# Altium schematic sheet cwh_userio.SchDoc
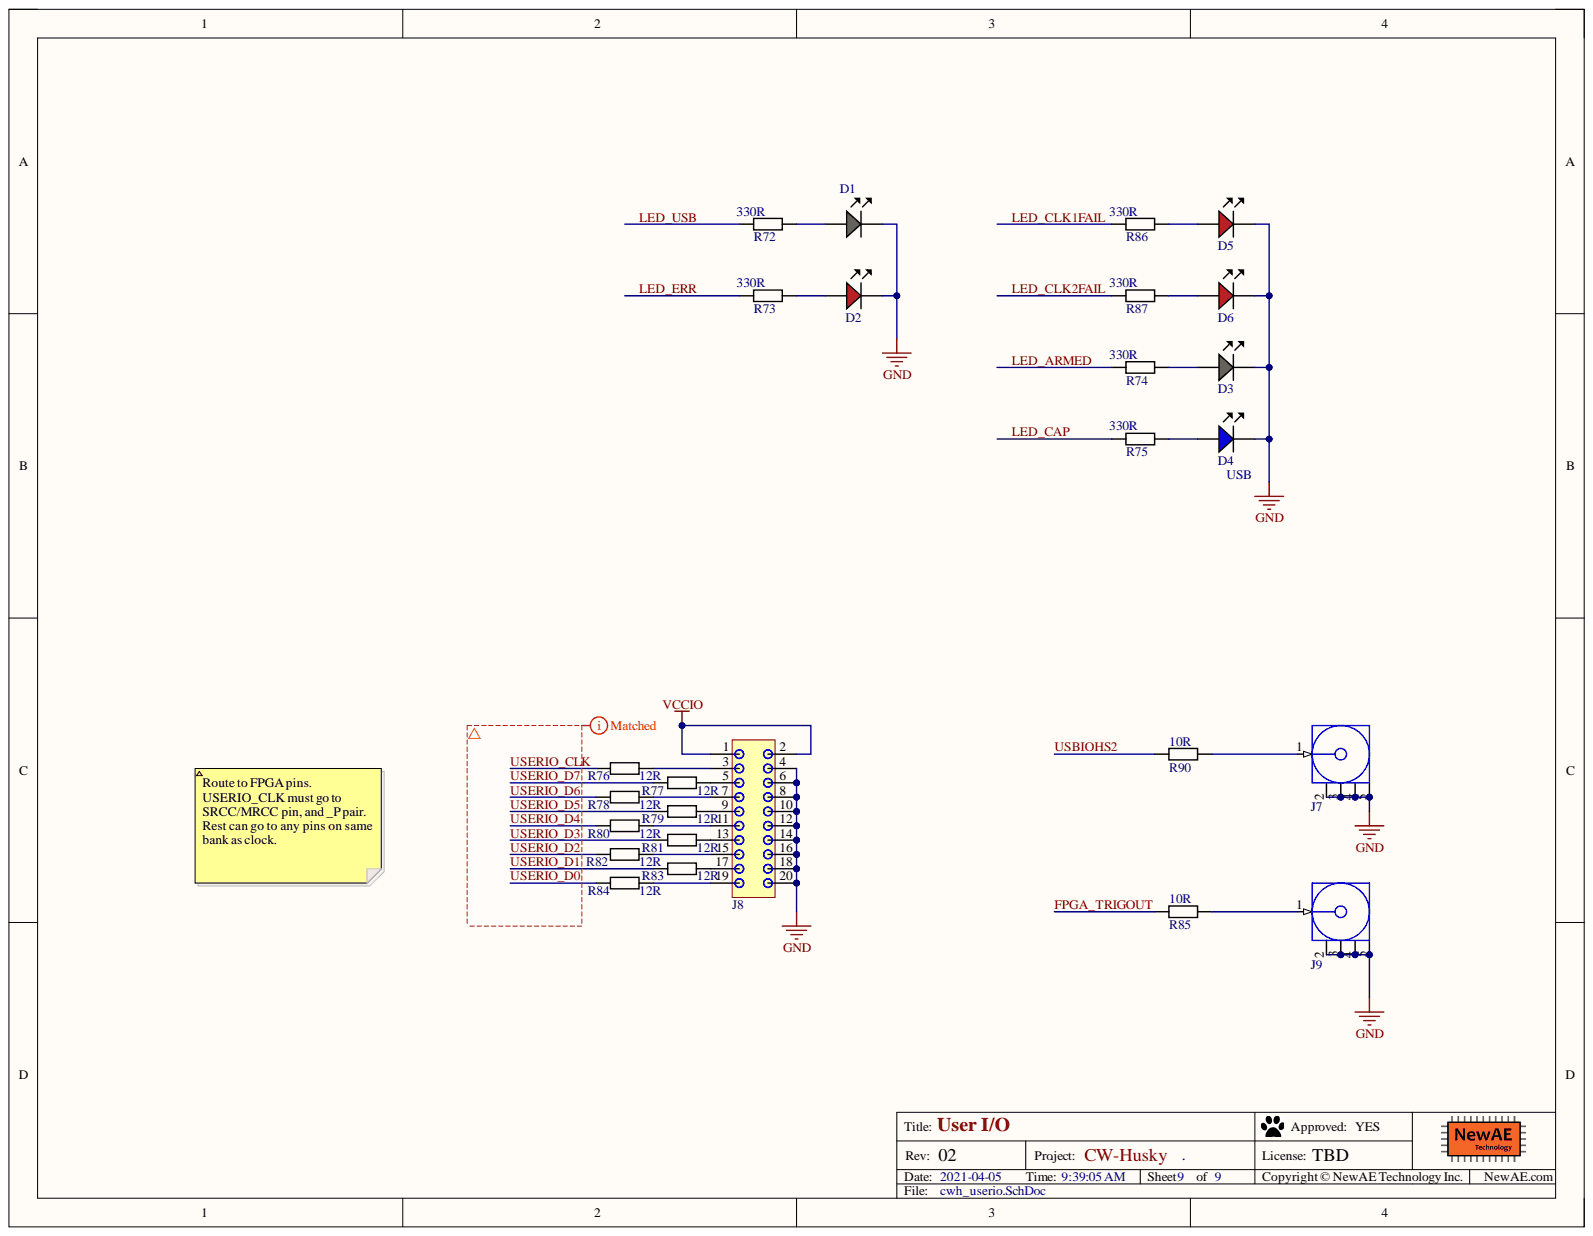
[diagram p0: cwh_userio.SchDoc]

## Parts
D1  (no value)  [LED-0603-GREEN]
D2  LED, 0603, Red  [LED-0603-RED]  (value not drawn)
D3  LED, 0603, Green  [LED-0603-GREEN]  (value not drawn)
D4  USB  [LED-0603-BLUE]
D5  LED, 0603, Red  [LED-0603-RED]  (value not drawn)
D6  LED, 0603, Red  [LED-0603-RED]  (value not drawn)
J7  MCX 733660061  [CONN_MCX_733660061]  (value not drawn)
J8  EW-10-09-G-D-300  [EW-10-09-G-D-300]  (value not drawn)
J9  MCX 733660061  [CONN_MCX_733660061]  (value not drawn)
R72  330R  [0603]
R73  330R  [0603]
R74  330R  [0603]
R75  330R  [0603]
R76  12R  [0402]
R77  12R  [0402]
R78  12R  [0402]
R79  12R  [0402]
R80  12R  [0402]
R81  12R  [0402]
R82  12R  [0402]
R83  12R  [0402]
R84  12R  [0402]
R85  10R  [0603]
R86  330R  [0603]
R87  330R  [0603]
R90  10R  [0603]

## Nets
GND: D1.K D2.K D3.K D4.K D5.K D6.K J7.2 J7.3 J7.4 J7.5 J8.4 J8.6 J8.8 J8.10 J8.12 J8.14 J8.16 J8.18 J8.20 J9.2 J9.3 J9.4 J9.5
VCCIO: J8.1 J8.2
FPGA_TRIGOUT: R85.1
LED_ARMED: R74.1
LED_CAP: R75.1
LED_CLK1FAIL: R86.1
LED_CLK2FAIL: R87.1
LED_ERR: R73.1
LED_USB: R72.1
USBIOHS2: R90.1
USERIO_CLK: R76.1
USERIO_D0: R84.1
USERIO_D1: R83.1
USERIO_D2: R82.1
USERIO_D3: R81.1
USERIO_D4: R80.1
USERIO_D5: R79.1
USERIO_D6: R78.1
USERIO_D7: R77.1
NetD1_A: D1.A R72.2
NetD2_A: D2.A R73.2
NetD3_A: D3.A R74.2
NetD4_A: D4.A R75.2
NetD5_A: D5.A R86.2
NetD6_A: D6.A R87.2
NetJ7_1: J7.1 R90.2
NetJ8_3: J8.3 R76.2
NetJ8_5: J8.5 R77.2
NetJ8_7: J8.7 R78.2
NetJ8_9: J8.9 R79.2
NetJ8_11: J8.11 R80.2
NetJ8_13: J8.13 R81.2
NetJ8_15: J8.15 R82.2
NetJ8_17: J8.17 R83.2
NetJ8_19: J8.19 R84.2
NetJ9_1: J9.1 R85.2

## Library data of the parts
D1 D3 = LEDCHIP_0603_GREEN: Chip LED, Green; Manufacture 1: Kingbright; Manufacture Part Number 1: APT1608CGCK; Component Group: Optical; Database Table Name: main; PP Material Stack: 16; PP Rotation: -90; Component Kind: Standard; Component Type: Standard; Library Name: NewAE_AltiumLib.DBLib; Mounting Technology: SMT; Part Number: LEDCHIP_0603_GREEN; Pin Count: 2; Supplier 1: Digi-Key; Supplier Part Number 1: 754-1116-1-ND
D2 D5 D6 = LEDCHIP_0603_RED: Chip LED, Red; Manufacture 1: Kingbright; Manufacture Part Number 1: APT1608SRCPRV; Component Group: Optical; Database Table Name: main; PP Material Stack: 15; PP Rotation: -90; Component Kind: Standard; Component Type: Standard; Library Name: NewAE_AltiumLib.DBLib; Mounting Technology: SMT; Part Number: LEDCHIP_0603_RED; Pin Count: 2; Supplier 1: Digi-Key; Supplier Part Number 1: 754-1122-1-ND
D4 = LEDCHIP_0603_BLUE: Chip LED, Blue; Manufacture 1: Kingbright; Component Group: Optical; Database Table Name: main; Component Kind: Standard; Component Type: Standard; Library Name: NewAE_AltiumLib.DBLib; Mounting Technology: SMT; Part Number: LEDCHIP_0603_BLUE; Pin Count: 2; Supplier 1: Digi-Key; Supplier Part Number 1: 754-1434-1-ND
J7 J9 = 733660061: MCX Straight; Manufacture Part Number 1: 29K101-400L5; Component Group: Connector; Database Table Name: main; PP Material Stack: 0; Component Kind: Standard; Component Type: Standard; Library Name: NewAE_AltiumLib.DBLib; Mounting Technology: Through-hole; Part Number: 733660061; Pin Count: 5; PP Rotation: 0; RoHS: Yes; Supplier 1: Digi-Key; Supplier Part Number 1: 1868-1160-ND
J8 = EW-10-09-G-D-300: 20-Pin Header, High-Top; Manufacture Part Number 1: SBH11-PBPC-D10-ST-BK; Component Group: Connector; Database Table Name: main; PP Material Stack: 0; Component Kind: Standard; Component Type: Standard; Library Name: NewAE_AltiumLib.DBLib; Part Number: SBH11-PBPC-D10-ST-BK; Pin Count: 20; PP Rotation: 0; Supplier 1: Digi-key; Supplier Part Number 1: S9172-ND
R72-R75 R86 R87 = RCHIP-330R-0603: 330R res, 0603, 1%, 1/10W; Manufacture 1: Yageo; Manufacture Part Number 1: RC0603FR-07330RL; Case-Metric: 1608; Component Group: Resistor; Database Table Name: main; Rated Power: 0.1W; Rated Voltage: 75VDC; Case-EIA: 0603; Component Kind: Standard; Component Type: Standard; Library Name: NewAE_AltiumLib.DBLib; Mounting Technology: SMT; Part Number: RCHIP-330R-0603; Pin Count: 2; Supplier 1: Digi-Key; Supplier Part Number 1: 311-330HRCT-ND; Temperature Range: -55C to 155C; Tolerance: 1%; Value: 330R
R76-R84 = RCHIP-12R-0402: 12R res, 0402, 1%, 1/16W; Manufacture 1: Yageo; Manufacture Part Number 1: RC0402FR-0712RL; Case-Metric: 1005; Component Group: Resistor; Database Table Name: main; Rated Power: 0.063W; Rated Voltage: 75VDC; Case-EIA: 0402; Component Kind: Standard; Component Type: Standard; Library Name: NewAE_AltiumLib.DBLib; Mounting Technology: SMT; Part Number: RCHIP-12R-0402; Pin Count: 2; Supplier 1: Digi-Key; Supplier Part Number 1: YAG2968CT-ND; Temperature Range: -55C to 155C; Tolerance: 1%; Value: 12R
R85 R90 = RCHIP-10R-0603: 10R res, 0603, 1%, 1/10W; Manufacture 1: Yageo; Manufacture Part Number 1: RC0603FR-0710RL; Case-Metric: 1608; Component Group: Resistor; Database Table Name: main; Rated Power: 0.1W; Rated Voltage: 75VDC; Case-EIA: 0603; Component Kind: Standard; Component Type: Standard; Library Name: NewAE_AltiumLib.DBLib; Mounting Technology: SMT; Part Number: RCHIP-10R-0603; Pin Count: 2; Supplier 1: Digi-Key; Supplier Part Number 1: 311-10.0HRCT-ND; Temperature Range: -55C to 155C; Tolerance: 1%; Value: 10R
